# Altium schematic sheet 04 主控部分.SchDoc("04 主控部分")
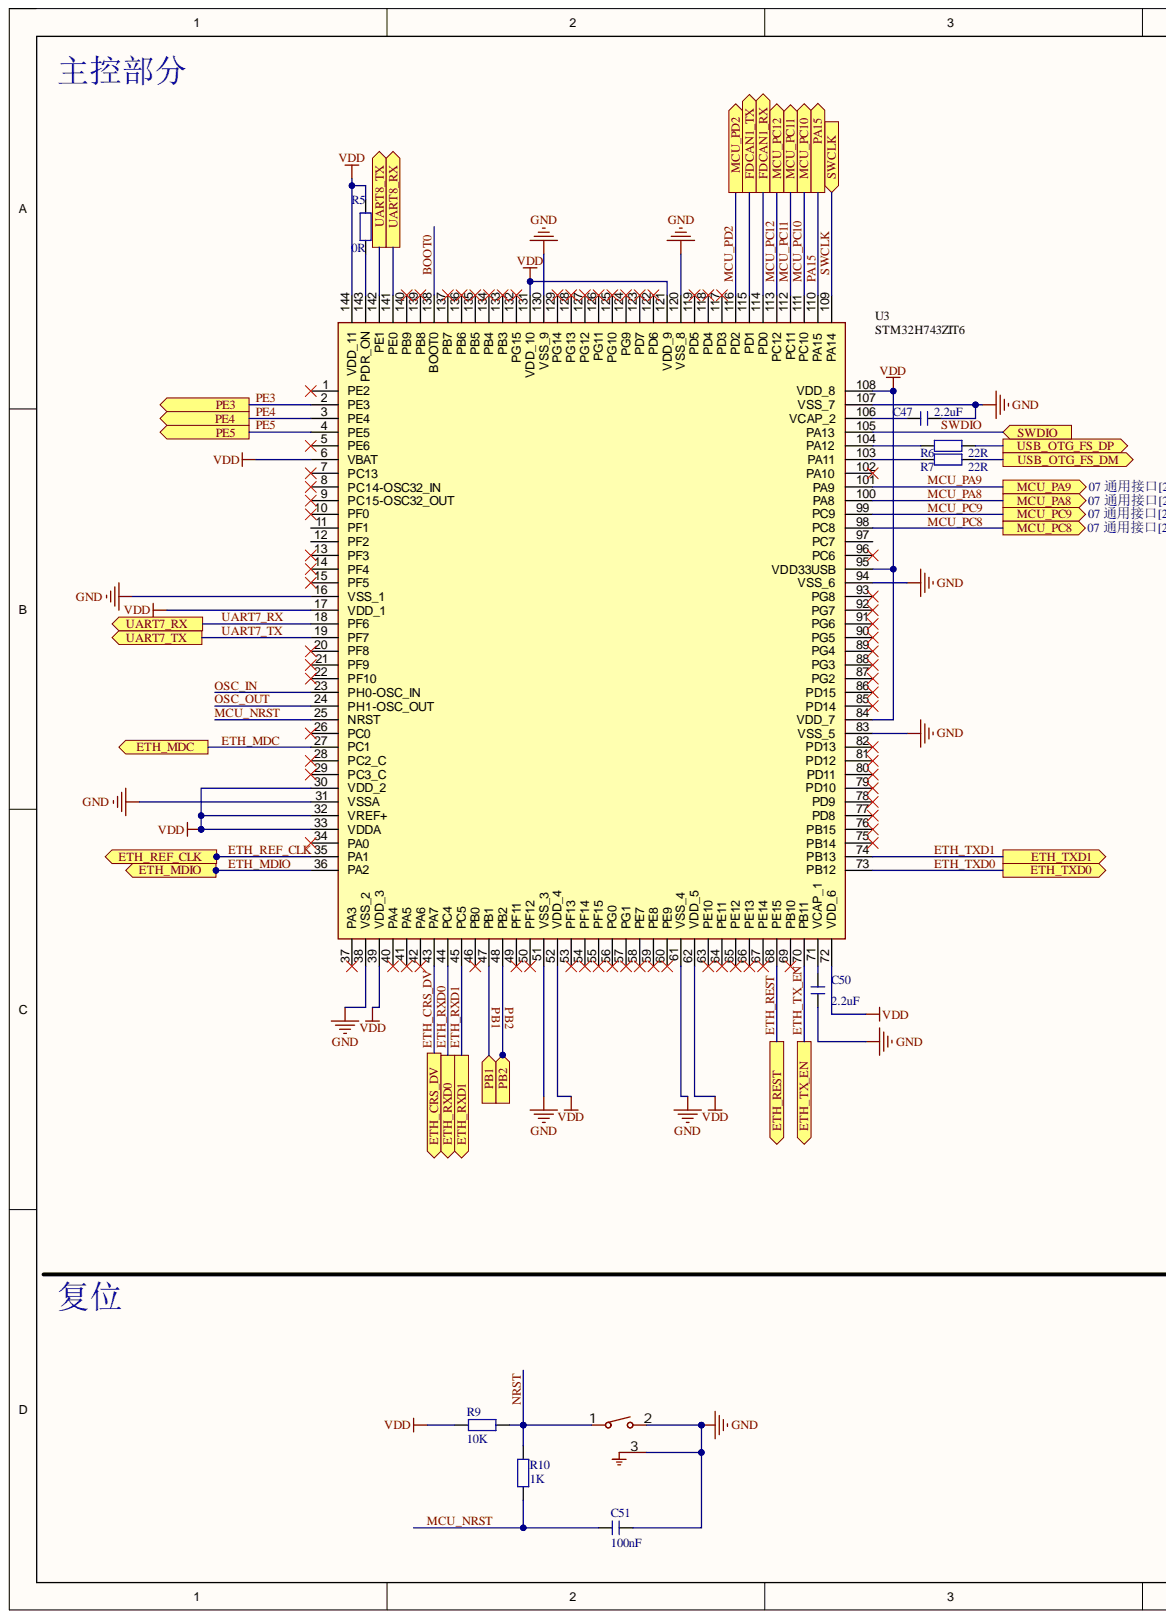
[diagram p0: 04 主控部分.SchDoc, left]
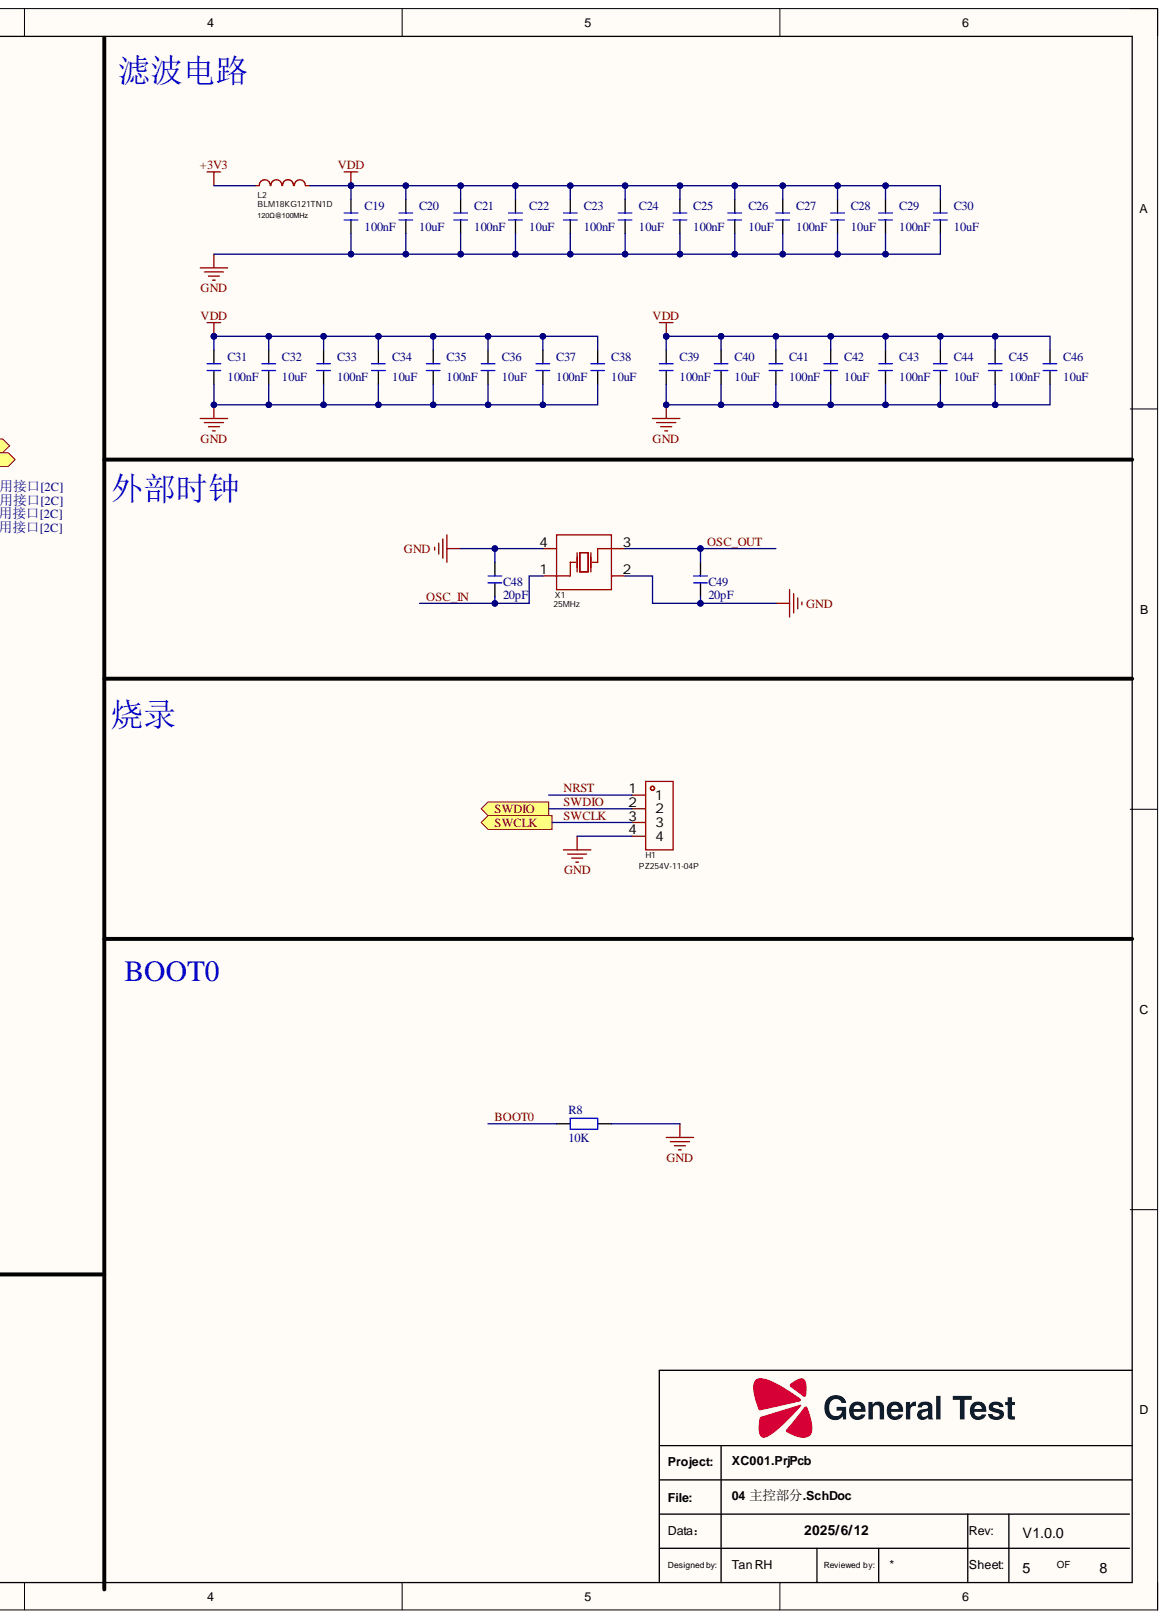
[diagram p1: 04 主控部分.SchDoc, right]

## Parts
C19  100nF  [C0402_L]
C20  10uF  [C0402_L]
C21  100nF  [C0402_L]
C22  10uF  [C0402_L]
C23  100nF  [C0402_L]
C24  10uF  [C0402_L]
C25  100nF  [C0402_L]
C26  10uF  [C0402_L]
C27  100nF  [C0402_L]
C28  10uF  [C0402_L]
C29  100nF  [C0402_L]
C30  10uF  [C0402_L]
C31  100nF  [C0402_L]
C32  10uF  [C0402_L]
C33  100nF  [C0402_L]
C34  10uF  [C0402_L]
C35  100nF  [C0402_L]
C36  10uF  [C0402_L]
C37  100nF  [C0402_L]
C38  10uF  [C0402_L]
C39  100nF  [C0402_L]
C40  10uF  [C0402_L]
C41  100nF  [C0402_L]
C42  10uF  [C0402_L]
C43  100nF  [C0402_L]
C44  10uF  [C0402_L]
C45  100nF  [C0402_L]
C46  10uF  [C0402_L]
C47  2.2uF  [C0402_L]
C48  20pF  [C0402_L]
C49  20pF  [C0402_L]
C50  2.2uF  [C0402_L]
C51  100nF  [C0402_L]
H1  PZ254V-11-04P  [HDR-TH_4P-P2.54-V-M]
L2  BLM18KG121TN1D  [BLM18EG101TH1D]
R5  0R  [R0402_L]
R6  22R  [R0402_L]
R7  22R  [R0402_L]
R8  10K  [R0402_L]
R9  10K  [R0402_L]
R10  1K  [R0402_L]
SW1  TS-1009B-05026  [SW-TH_3P-L4.5-W3.8-P3.10-LS1.9]  (value not drawn)
U3  STM32H743ZIT6  [QFP50P2200X2200X160-144N]
X1  25MHz  [CRYSTAL-SMD_4P-L3.2-W2.5-BL-2]

## Ports
ETH_CRS_DV, ETH_MDC, ETH_MDIO, ETH_REF_CLK, ETH_REST, ETH_RXD0, ETH_RXD1, ETH_TX_EN, ETH_TXD0, ETH_TXD1, FDCAN1_RX, FDCAN1_TX, MCU_PA8, MCU_PA9, MCU_PC8, MCU_PC9, MCU_PC10, MCU_PC11, MCU_PC12, MCU_PD2, PA15, PB1, PB2, PE3, PE4, PE5, SWCLK, SWDIO, UART7_RX, UART7_TX, UART8_RX, UART8_TX, USB_OTG_FS_DM, USB_OTG_FS_DP

## Nets
+3V3: L2.1
GND: C19.1 C20.1 C21.1 C22.1 C23.1 C24.1 C25.1 C26.1 C27.1 C28.1 C29.1 C30.1 C31.1 C32.1 C33.1 C34.1 C35.1 C36.1 C37.1 C38.1 C39.1 C40.1 C41.1 C42.1 C43.1 C44.1 C45.1 C46.1 C47.1 C48.1 C49.2 C50.1 C51.1 H1.4 R8.1 SW1.2 SW1.3 U3.16(VSS_1) U3.31(VSSA) U3.38(VSS_2) U3.51(VSS_3) U3.61(VSS_4) U3.83(VSS_5) U3.94(VSS_6) U3.107(VSS_7) U3.120(VSS_8) U3.130(VSS_9) X1.2 X1.4
VDD: C19.2 C20.2 C21.2 C22.2 C23.2 C24.2 C25.2 C26.2 C27.2 C28.2 C29.2 C30.2 C31.2 C32.2 C33.2 C34.2 C35.2 C36.2 C37.2 C38.2 C39.2 C40.2 C41.2 C42.2 C43.2 C44.2 C45.2 C46.2 L2.2 R5.2 R9.2 U3.6(VBAT) U3.17(VDD_1) U3.30(VDD_2) U3.32(VREF+) U3.33(VDDA) U3.39(VDD_3) U3.52(VDD_4) U3.62(VDD_5) U3.72(VDD_6) U3.84(VDD_7) U3.95(VDD33USB) U3.108(VDD_8) U3.121(VDD_9) U3.131(VDD_10) U3.144(VDD_11)
BOOT0: R8.2 U3.138(BOOT0)
ETH_CRS_DV: U3.43(PA7) ; port ETH_CRS_DV
ETH_MDC: U3.27(PC1) ; port ETH_MDC
ETH_MDIO: U3.36(PA2) ; port ETH_MDIO
ETH_REF_CLK: U3.35(PA1) ; port ETH_REF_CLK
ETH_REST: U3.68(PE15) ; port ETH_REST
ETH_RXD0: U3.44(PC4) ; port ETH_RXD0
ETH_RXD1: U3.45(PC5) ; port ETH_RXD1
ETH_TX_EN: U3.70(PB11) ; port ETH_TX_EN
ETH_TXD0: U3.73(PB12) ; port ETH_TXD0
ETH_TXD1: U3.74(PB13) ; port ETH_TXD1
FDCAN1_RX: U3.114(PD0) ; port FDCAN1_RX
FDCAN1_TX: U3.115(PD1) ; port FDCAN1_TX
MCU_NRST: C51.2 R10.2 U3.25(NRST)
MCU_PA8: U3.100(PA8) ; port MCU_PA8
MCU_PA9: U3.101(PA9) ; port MCU_PA9
MCU_PC8: U3.98(PC8) ; port MCU_PC8
MCU_PC9: U3.99(PC9) ; port MCU_PC9
MCU_PC10: U3.111(PC10) ; port MCU_PC10
MCU_PC11: U3.112(PC11) ; port MCU_PC11
MCU_PC12: U3.113(PC12) ; port MCU_PC12
MCU_PD2: U3.116(PD2) ; port MCU_PD2
NRST: H1.1 R9.1 R10.1 SW1.1
OSC_IN: C48.2 U3.23(PH0-OSC_IN) X1.1
OSC_OUT: C49.1 U3.24(PH1-OSC_OUT) X1.3
PA15: U3.110(PA15) ; port PA15
PB1: U3.47(PB1) ; port PB1
PB2: U3.48(PB2) ; port PB2
PE3: U3.2(PE3) ; port PE3
PE4: U3.3(PE4) ; port PE4
PE5: U3.4(PE5) ; port PE5
SWCLK: H1.3 U3.109(PA14) ; port SWCLK
SWDIO: H1.2 U3.105(PA13) ; port SWDIO
UART7_RX: U3.18(PF6) ; port UART7_RX
UART7_TX: U3.19(PF7) ; port UART7_TX
UART8_RX: U3.141(PE0) ; port UART8_RX
UART8_TX: U3.142(PE1) ; port UART8_TX
USB_OTG_FS_DM: R7.1 ; port USB_OTG_FS_DM
USB_OTG_FS_DP: R6.1 ; port USB_OTG_FS_DP
NetC47_2: C47.2 U3.106(VCAP_2)
NetC50_2: C50.2 U3.71(VCAP_1)
NetR5_1: R5.1 U3.143(PDR_ON)
NetR6_2: R6.2 U3.104(PA12)
NetR7_2: R7.2 U3.103(PA11)

Not connected: U3 1(PE2) 5(PE6) 7(PC13) 8(PC14-OSC32_IN) 9(PC15-OSC32_OUT) 10(PF0) 11(PF1) 12(PF2) 13(PF3) 14(PF4) 15(PF5) 20(PF8) 21(PF9) 22(PF10) 26(PC0) 28(PC2_C) 29(PC3_C) 34(PA0) 37(PA3) 40(PA4) 41(PA5) 42(PA6) 46(PB0) 49(PF11) 50(PF12) 53(PF13) 54(PF14) 55(PF15) 56(PG0) 57(PG1) 58(PE7) 59(PE8) 60(PE9) 63(PE10) 64(PE11) 65(PE12) 66(PE13) 67(PE14) 69(PB10) 75(PB14) 76(PB15) 77(PD8) 78(PD9) 79(PD10) 80(PD11) 81(PD12) 82(PD13) 85(PD14) 86(PD15) 87(PG2) 88(PG3) 89(PG4) 90(PG5) 91(PG6) 92(PG7) 93(PG8) 96(PC6) 97(PC7) 102(PA10) 117(PD3) 118(PD4) 119(PD5) 122(PD6) 123(PD7) 124(PG9) 125(PG10) 126(PG11) 127(PG12) 128(PG13) 129(PG14) 132(PG15) 133(PB3) 134(PB4) 135(PB5) 136(PB6) 137(PB7) 139(PB8) 140(PB9)

## Library data of the parts
C19 C21 C23 C25 C27 C29 C31 C33 C35 C37 C39 C41 C43 C45 = C: 贴片电容; BOM名称: 无极性贴片电容; LibRef: GRM155R71C104JA88D; 淘宝ID: 封装库来自淘宝 ID：黄晶宝石; Component Kind: Standard; Library Name: 0.1 - 电阻-电容-电感.SchLib; 唯一出售店铺网址: https://shop463601444.taobao.com; 联系售后，技术支持: https://shop463601444.taobao.com
C20 C22 C24 C26 C28 C30 C32 C34 C36 C38 C40 C42 C44 C46 = C: 贴片电容; BOM名称: 无极性贴片电容; LibRef: GRM155C80J106ME18D; 淘宝ID: 封装库来自淘宝 ID：黄晶宝石; Component Kind: Standard; Library Name: 0.1 - 电阻-电容-电感.SchLib; 唯一出售店铺网址: https://shop463601444.taobao.com; 联系售后，技术支持: https://shop463601444.taobao.com
  C26: Parameter 1: GRM155C80J106ME18D
C47 C50 C51 = C: 贴片电容; BOM名称: 无极性贴片电容; 淘宝ID: 封装库来自淘宝 ID：黄晶宝石; Component Kind: Standard; Library Name: 0.1 - 电阻-电容-电感.SchLib; 唯一出售店铺网址: https://shop463601444.taobao.com; 联系售后，技术支持: https://shop463601444.taobao.com
C48 C49 = C: 贴片电容; BOM名称: 无极性贴片电容; LibRef: GRM1555C1H180FA01D; 淘宝ID: 封装库来自淘宝 ID：黄晶宝石; Component Kind: Standard; Library Name: 0.1 - 电阻-电容-电感.SchLib; 唯一出售店铺网址: https://shop463601444.taobao.com; 联系售后，技术支持: https://shop463601444.taobao.com
H1 = PZ254V-11-04P: Manufacturer: XFCN(兴飞); Add into BOM: yes; Circluar Pins/Square Pins: 方针; Current Rating (Max): 3A; Pin Structure: 1x4P; Pitch: 2.54mm; Symbol: PZ254V-11-04P_C2691448; Voltage Rating (Max): 250V; Color: 黑色; Component Kind: Standard; Contact Material: -; Convert to PCB: yes; Datasheet: https://atta.szlcsc.com/upload/public/pdf/source/20200309/C492403_F9F19131791D08044AD4F84F8E751A34.pdf; Device: PZ254V-11-04P; Insulation Height: 2.5mm; JLCPCB Part Class: Extended Part; LCSC Part Name: 间距:2.54mm 1x4P 直插 方针; Length of End Connection Pin: 3mm; Length of Mating Pin: 6mm; Library Name: Schlib1.SchLib; Manufacturer Part: PZ254V-11-04P; Mounting Type: 直插; Number of Pins: 4P; Number of Rows: 单排; Operating Temperature Range: -40℃~+105℃; PCB3D: HDR-TH_4P-P2.54-M-LI-1X4P; Row Spacing: -; Supplier: LCSC; Supplier Footprint: 插件,P=2.54mm; Supplier Part: C2691448
L2 = BLM18KG121TN1D: 120Ω@100MHz; Manufacturer: muRata(村田); Add into BOM: yes; Circuits: 1; Current Rating: 3A; DC Resistance: 30mΩ; Tolerance: ±25%; Component Kind: Standard; Convert to PCB: yes; Datasheet: https://atta.szlcsc.com/upload/public/pdf/source/20180329/C160981_FD3C2D2622A377E203B89F4AA32B0741.pdf; Device: BLM18KG121TN1D; Impedance @ Frequency: 120Ω@100MHz; JLCPCB Part Class: Extended Part; LCSC Part Name: 单路 0603磁珠 阻抗120Ω@100MHz; Library Name: Schlib1.SchLib; Manufacturer Part: BLM18KG121TN1D; Supplier: LCSC; Supplier Footprint: 0603; Supplier Part: C85831; Symbol: BLM18KG121TN1D
R5-R7 R10 = R: 贴片电阻; 封装库来自淘宝卖家 ID：黄晶宝石: 其他店铺购入均为盗版，不享受售后技术支持服务，请立即申请退款; 版权归属: 来自淘宝 ID：黄晶宝石; BOM名称: 贴片电阻; Component Kind: Standard; Library Name: 0.1 - 电阻-电容-电感.SchLib; 联系售后: https://item.taobao.com/item.htm?id=566775463719
R8 R9 = R: 贴片电阻; LibRef: ERA2AEB103X; 封装库来自淘宝卖家 ID：黄晶宝石: 其他店铺购入均为盗版，不享受售后技术支持服务，请立即申请退款; 版权归属: 来自淘宝 ID：黄晶宝石; BOM名称: 贴片电阻; Component Kind: Standard; Library Name: 0.1 - 电阻-电容-电感.SchLib; 联系售后: https://item.taobao.com/item.htm?id=566775463719
SW1 = TS-1009B-05026: Manufacturer: XUNPU(讯普); Actuator Style: 圆形按钮; Add into BOM: yes; Circuit: 单刀单掷; Contact Current: 50mA; Switch Height: 5mm; Switch Length: 4.5mm; Voltage Rating (DC): 12V; Component Kind: Standard; Convert to PCB: yes; Datasheet: https://atta.szlcsc.com/upload/public/pdf/source/20191118/C455252_152893B36BC47A2689682BC2AA7C27A7.pdf; Device: TS-1009B-05026; JLCPCB Part Class: Extended Part; LCSC Part Name: 4.5*4.5*5mm 卧插 轻触开关; Library Name: Stm32h743 Pcb.SchLib; Manufacturer Part: TS-1009B-05026; Mechanical Life: -; Mounting Style: 卧插; PCB3D: SW-TH_3P-L4.5-W3.8-P3.10-LS1.9; Supplier: LCSC; Supplier Footprint: 插件,4.5x4.5mm; Supplier Part: C455252; Switch Width: 4.5mm; Symbol: TS-1009B-05026; With Lamp: -
U3 = STM32H743ZIT6: Integrated Circuit; Manufacturer_Name: STMicroelectronics; Arrow Part Number: STM32H743ZIT6; Arrow Price/Stock: https://www.arrow.com/en/products/stm32h743zit6/stmicroelectronics?utm_currency=USD&region=nac; Component Kind: Standard; Datasheet Link: https://www.st.com/resource/en/datasheet/stm32h743zi.pdf; Height: 1.6mm; Manufacturer_Part_Number: STM32H743ZIT6; Mouser Part Number: 511-STM32H743ZIT6; Mouser Price/Stock: https://www.mouser.co.uk/ProductDetail/STMicroelectronics/STM32H743ZIT6?qs=FNcb6ahWXRyuf15GdgTu%252Bw%3D%3D
X1 = X322525MSB4SI: Manufacturer: YXC(扬兴晶振); Add into BOM: yes; Crystal Type: 贴片晶振; Frequency Stability(Full temperature range): ±20ppm; Load Capacitor: 20pF; Normal temperature Frequency Tolerance: ±10ppm; Component Kind: Standard; Convert to PCB: yes; Datasheet: https://atta.szlcsc.com/upload/public/pdf/source/20240329/19D5F850207469550BEB00D7DFEDBC7A.pdf; Device: X322525MSB4SI; JLCPCB Part Class: Extended Part; LCSC Part Name: 25MHz ±10ppm 20pF; Library Name: Stm32h743 Pcb.SchLib; Manufacturer Part: X322525MSB4SI; Operating Temperature: -40℃~+85℃; Supplier: LCSC; Supplier Footprint: SMD3225-4P; Supplier Part: C13740; Symbol: X322525MSB4SI; Value: 25MHz
